# Altium schematic sheet Sheet3.SchDoc
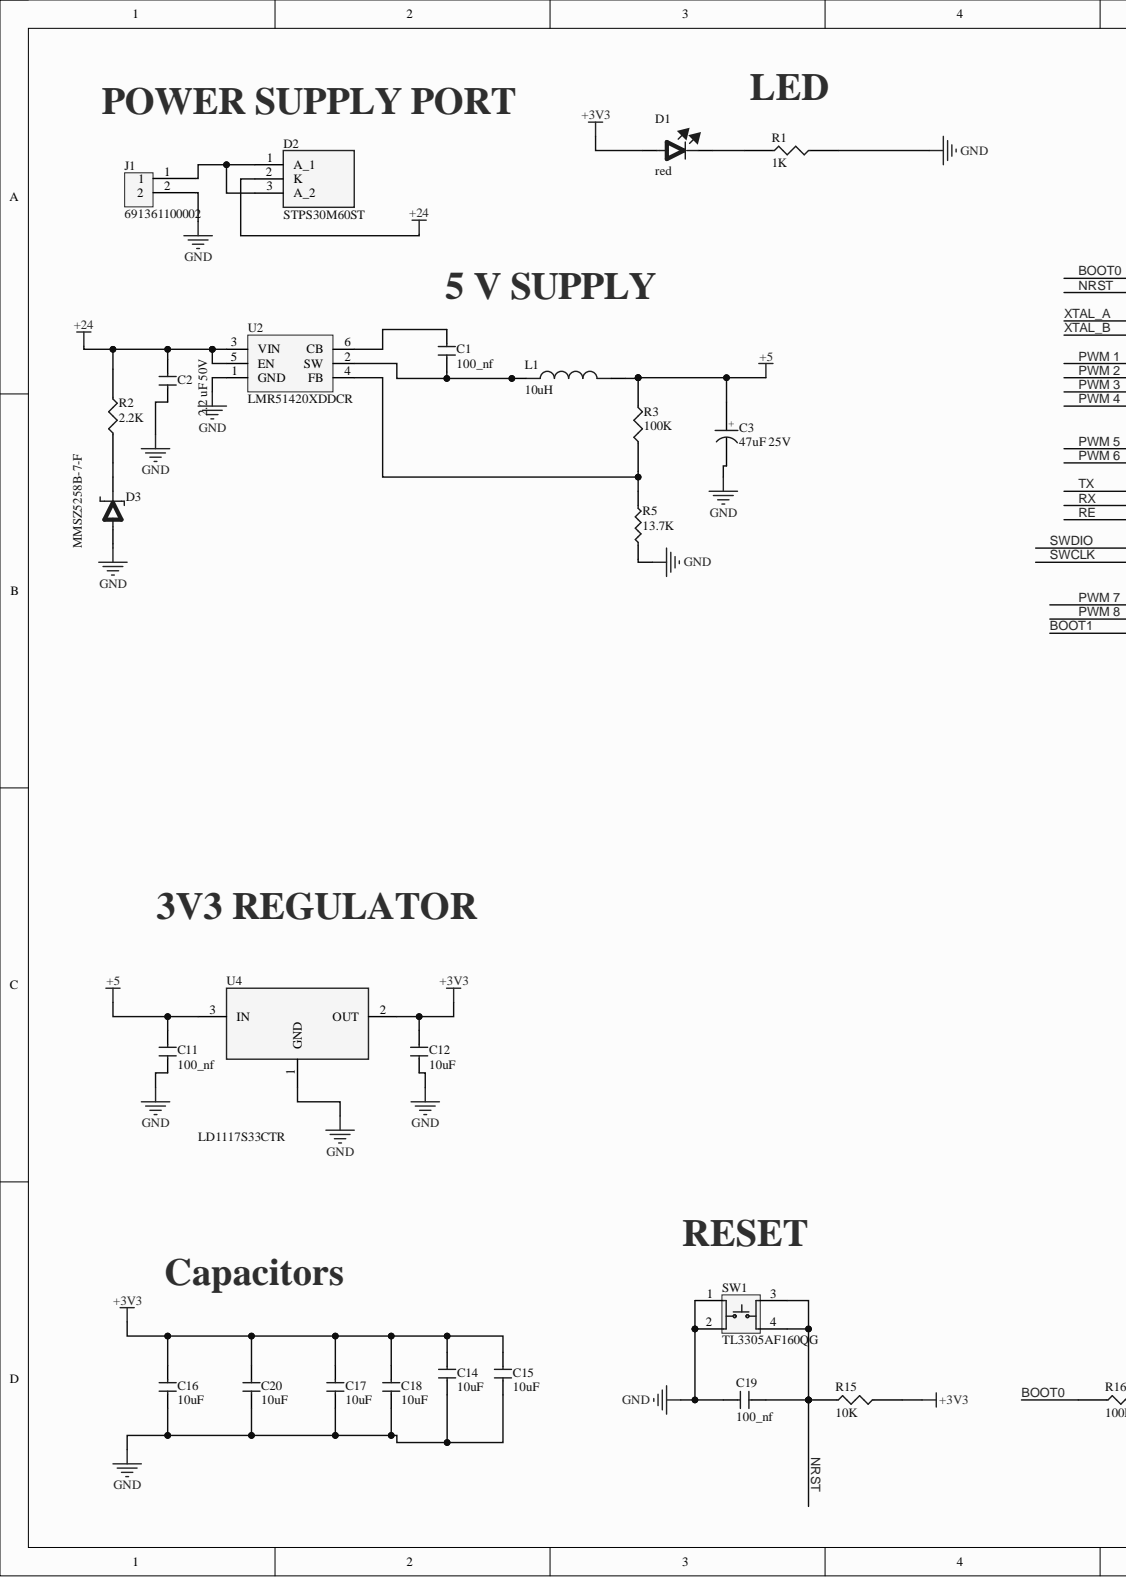
[diagram p0: Sheet3.SchDoc, left]
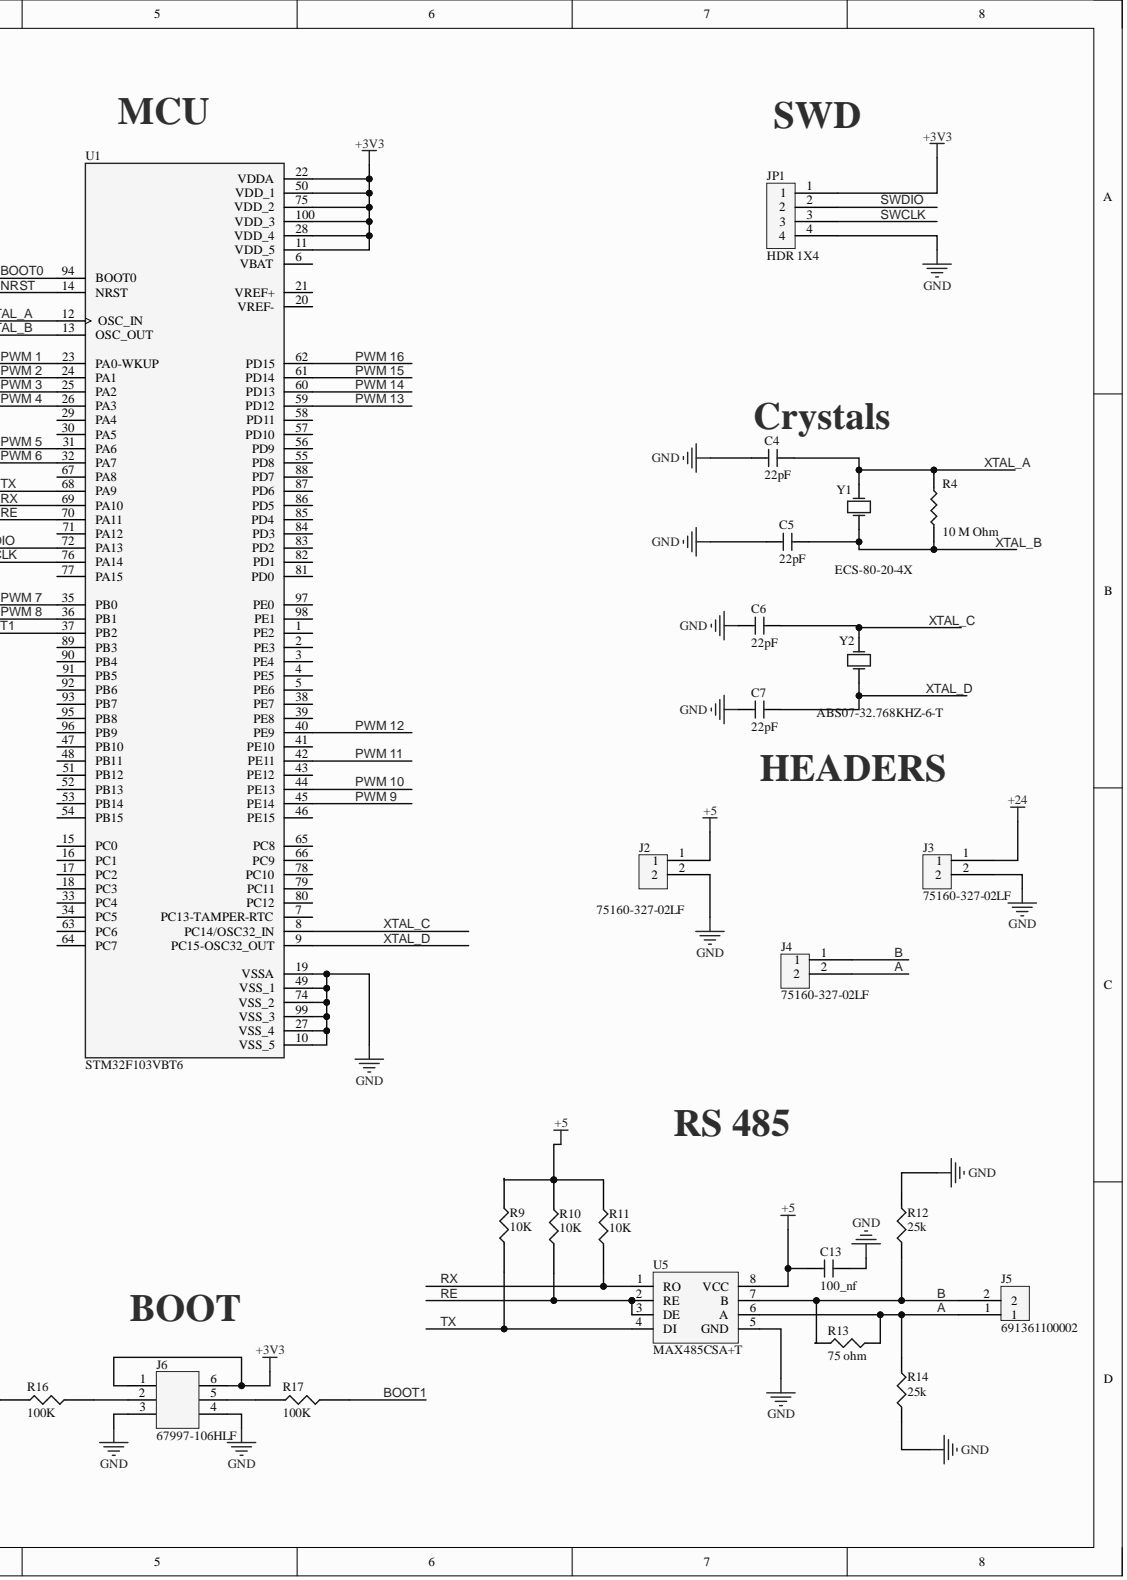
[diagram p1: Sheet3.SchDoc, right]

## Parts
C1  100_nf  [CAP_0805]
C2  2.2 uF 50V  Voltage Rating: 50V  [CAP_0805]
C3  47uF 25V  Capacitance: 47uF  Voltage Rating: 25V  [CAP_ALU_D_Size]
C4  22pF  [CAP_0805]
C5  22pF  [CAP_0805]
C6  22pF  [CAP_0805]
C7  22pF  [CAP_0805]
C11  100_nf  [CAP_0805]
C12  10uF  [CAP_0805]
C13  100_nf  [CAP_0805]
C14  10uF  [CAP_0805]
C15  10uF  [CAP_0805]
C16  10uF  [CAP_0805]
C17  10uF  [CAP_0805]
C18  10uF  [CAP_0805]
C19  100_nf  [CAP_0805]
C20  10uF  [CAP_0805]
D1  red  [LED_RED_0805]
D2  STPS30M60ST  [TO255P460X1020X2008-3P]
D3  MMSZ5258B-7-F  [SOD-123]
J1  691361100002  [Screw Terminal 3.5mm]
J2  75160-327-02LF  [HDR 1x2]
J3  75160-327-02LF  [HDR 1x2]
J4  75160-327-02LF  [HDR 1x2]
J5  691361100002  [Screw Terminal 3.5mm]
J6  67997-106HLF  [MALE_HDR_3X2_2.54mm]
JP1  HDR 1X4  [HDR 1X4]
L1  10uH  [B952AS-H-100M=P3]
R1  1K  [R_0805]
R2  2.2K  [R_0805]
R3  100K  [R_0805]
R4  10 M Ohm  [R_0805]
R5  13.7K  [R_0805]
R9  10K  [R_0805]
R10  10K  [R_0805]
R11  10K  [R_0805]
R12  25k  [R_0805]
R13  75 ohm  [R_0805]
R14  25k  [R_0805]
R15  10K  [R_0805]
R16  100K  [R_0805]
R17  100K  [R_0805]
SW1  TL3305AF160QG  [SW_PB_SMD]
U1  STM32F103VBT6  [STM32F103VBT6]
U2  LMR51420XDDCR  [SOT 23-6]
U4  LD1117S33CTR  [SOT 223 3]
U5  MAX485CSA+T  [8 SOIC]
Y1  ECS-80-20-4X  [XTAL_8_MHZ]
Y2  ABS07-32.768KHZ-6-T  [XTAL_32.768 KHZ_SMD]

## Nets
+3V3: C12.2 C14.1 C15.1 C16.1 C17.1 C18.1 C20.1 D1.2 J6.1 J6.6 JP1.1 R15.2 U1.11(VDD_5) U1.22(VDDA) U1.28(VDD_4) U1.50(VDD_1) U1.75(VDD_2) U1.100(VDD_3) U4.2
+5: C3.1 C11.1 C13.1 J2.1 L1.2 R3.2 R9.1 R10.1 R11.1 U4.3 U5.8(VCC)
+24: C2.1 D2.2 J3.1 R2.2 U2.3(VIN) U2.5(EN)
GND: C2.2 C3.2 C4.1 C5.1 C6.1 C7.1 C11.2 C12.1 C13.2 C14.2 C15.2 C16.2 C17.2 C18.2 C19.1 C20.2 D3.2 J1.2 J2.2 J3.2 J6.3 J6.4 JP1.4 R1.2 R5.2 R12.2 R14.1 SW1.1 SW1.2 U1.10(VSS_5) U1.19(VSSA) U1.27(VSS_4) U1.49(VSS_1) U1.74(VSS_2) U1.99(VSS_3) U2.1(GND) U4.1 U5.5(GND)
A: J4.2 J5.1 R13.2 R14.2 U5.6(A)
B: J4.1 J5.2 R12.1 R13.1 U5.7(B)
BOOT0: R16.1 U1.94(BOOT0)
BOOT1: R17.2 U1.37(PB2)
NRST: C19.2 R15.1 SW1.3 SW1.4 U1.14(NRST)
PWM 1: U1.23(PA0-WKUP)
PWM 2: U1.24(PA1)
PWM 3: U1.25(PA2)
PWM 4: U1.26(PA3)
PWM 5: U1.31(PA6)
PWM 6: U1.32(PA7)
PWM 7: U1.35(PB0)
PWM 8: U1.36(PB1)
PWM 9: U1.45(PE14)
PWM 10: U1.44(PE13)
PWM 11: U1.42(PE11)
PWM 12: U1.40(PE9)
PWM 13: U1.59(PD12)
PWM 14: U1.60(PD13)
PWM 15: U1.61(PD14)
PWM 16: U1.62(PD15)
RE: R10.2 U1.70(PA11) U5.2(RE) U5.3(DE)
RX: R11.2 U1.69(PA10) U5.1(RO)
SWCLK: JP1.3 U1.76(PA14)
SWDIO: JP1.2 U1.72(PA13)
TX: R9.2 U1.68(PA9) U5.4(DI)
XTAL_A: C4.2 R4.1 U1.12(OSC_IN) Y1.1
XTAL_B: C5.2 R4.2 U1.13(OSC_OUT) Y1.2
XTAL_C: C6.2 U1.8(PC14/OSC32_IN) Y2.1
XTAL_D: C7.2 U1.9(PC15-OSC32_OUT) Y2.2
NetC1_1: C1.1 U2.6(CB)
NetC1_2: C1.2 L1.1 U2.2(SW)
NetD1_1: D1.1 R1.1
NetD2_1: D2.1 D2.3 J1.1
NetD3_1: D3.1 R2.1
NetJ6_2: J6.2 R16.2
NetJ6_5: J6.5 R17.1
NetR3_1: R3.1 R5.1 U2.4(FB)

Not connected: U1 1(PE2) 2(PE3) 3(PE4) 4(PE5) 5(PE6) 6(VBAT) 7(PC13-TAMPER-RTC) 15(PC0) 16(PC1) 17(PC2) 18(PC3) 20(VREF-) 21(VREF+) 29(PA4) 30(PA5) 33(PC4) 34(PC5) 38(PE7) 39(PE8) 41(PE10) 43(PE12) 46(PE15) 47(PB10) 48(PB11) 51(PB12) 52(PB13) 53(PB14) 54(PB15) 55(PD8) 56(PD9) 57(PD10) 58(PD11) 63(PC6) 64(PC7) 65(PC8) 66(PC9) 67(PA8) 71(PA12) 77(PA15) 78(PC10) 79(PC11) 80(PC12) 81(PD0) 82(PD1) 83(PD2) 84(PD3) 85(PD4) 86(PD5) 87(PD6) 88(PD7) 89(PB3) 90(PB4) 91(PB5) 92(PB6) 93(PB7) 95(PB8) 96(PB9) 97(PE0) 98(PE1)
